# Altium schematic sheet battery_charger.SchDoc
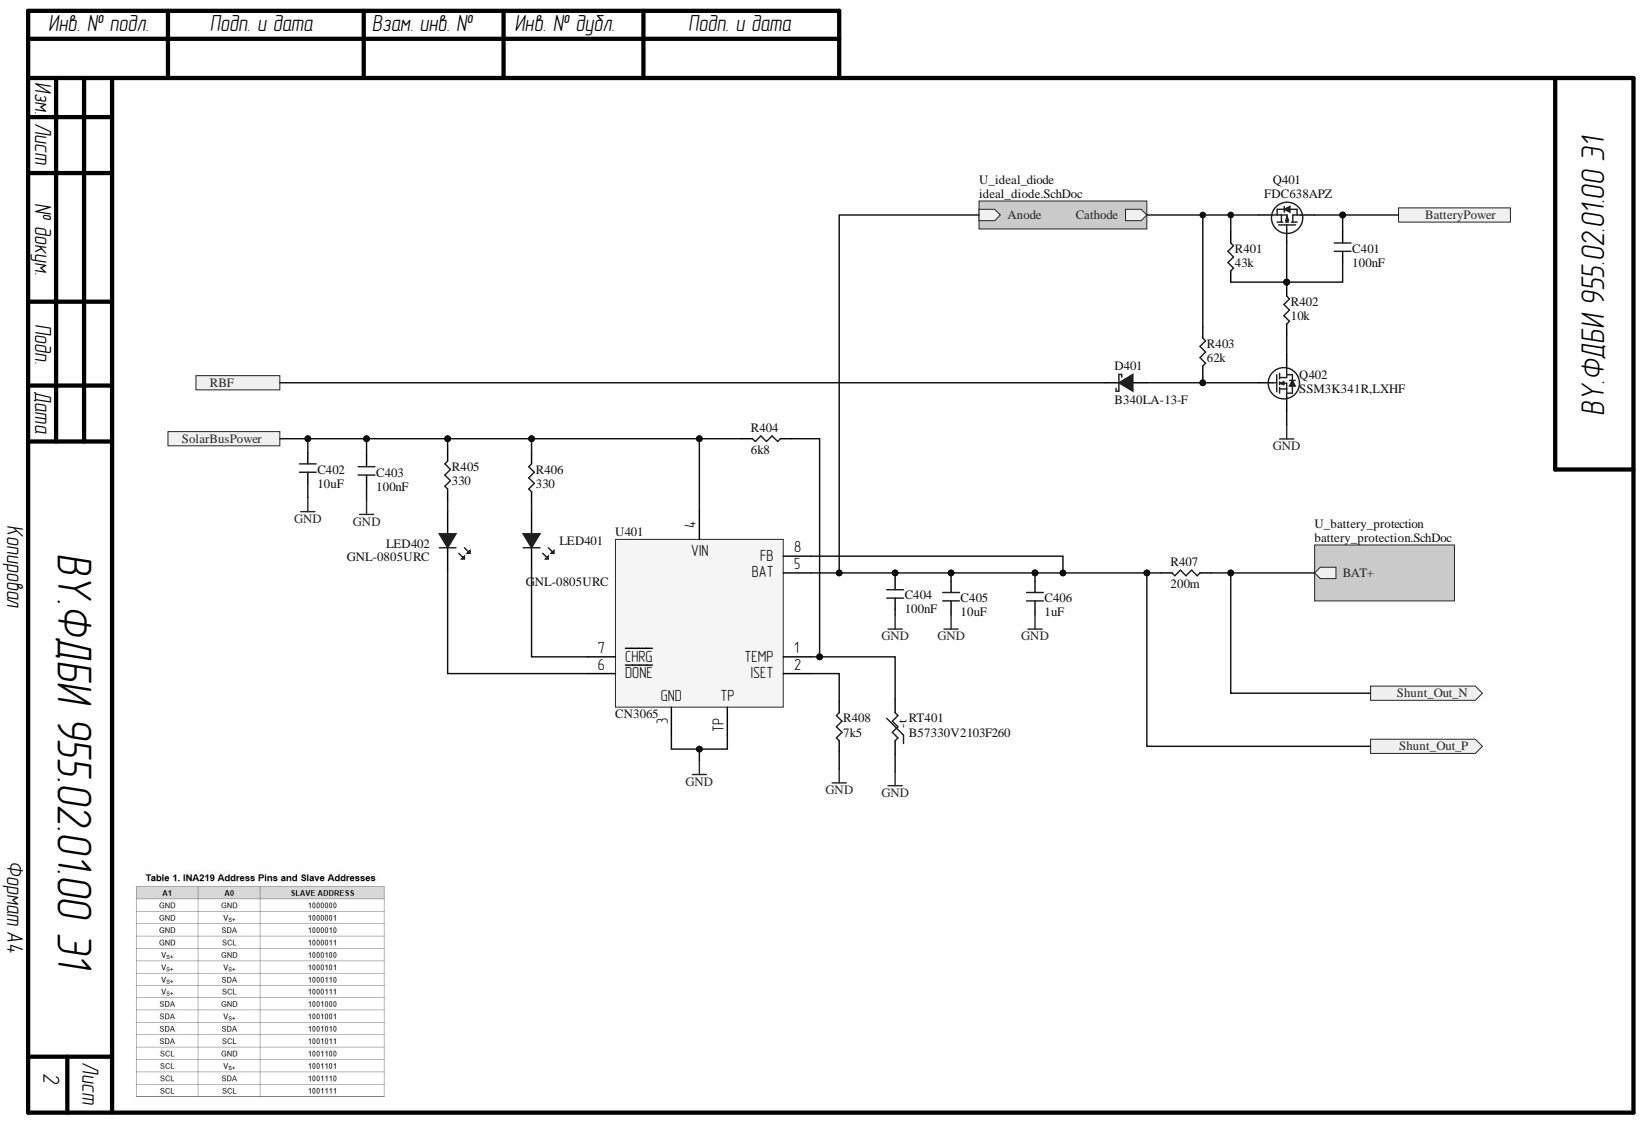
[diagram p0: battery_charger.SchDoc]

## Parts
C401  100nF  [CAP0603]
C402  10uF  [CAP0805]
C403  100nF  [CAP0603]
C404  100nF  [CAP0603]
C405  10uF  [CAP0805]
C406  1uF  [CAP0603]
D401  B340LA-13-F  [DO-214AC, SMA]
LED401  GNL-0805URC  [LED0805]
LED402  GNL-0805URC  [LED0805]
Q401  FDC638APZ  [SOT-23-6-MOSFET]
Q402  SSM3K341R,LXHF  [SOT-23-3-MOSFET]
R401  43k  [RES0603]
R402  10k  [RES0603]
R403  62k  [RES0603]
R404  6k8  [RES0603]
R405  330  [RES0603]
R406  330  [RES0603]
R407  200m  [RES1206]
R408  7k5  [RES0603]
RT401  B57330V2103F260  [RES0603]
U401  CN3065  [DFN-8_3x3_05]

## Ports
BatteryPower, RBF, Shunt_Out_N, Shunt_Out_P, SolarBusPower

## Nets
GND: C402.1 C403.1 C404.1 C405.1 C406.1 Q402.S R408.1 RT401.1 U401.3 U401.TP
BatteryPower: C401.2 Q401.D ; port BatteryPower
RBF: D401.1 ; port RBF
Shunt_Out_N: R407.2 ; port Shunt_Out_N
Shunt_Out_P: C404.2 C405.2 C406.2 R407.1 U401.5(BAT) U401.8(FB) ; port Shunt_Out_P
SolarBusPower: C402.2 C403.2 R404.1 R405.2 R406.2 U401.4 ; port SolarBusPower
NetC401_1: C401.1 Q401.G R401.1 R402.2
NetD401_2: D401.2 Q402.G R403.1
NetLED401_A: LED401.A R406.1
NetLED401_C: LED401.C U401.7(CHRG)
NetLED402_A: LED402.A R405.1
NetLED402_C: LED402.C U401.6(DONE)
NetQ401_S: Q401.S R401.2 R403.2
NetQ402_D: Q402.D R402.1
NetR404_2: R404.2 RT401.2 U401.1(TEMP)
NetR408_2: R408.2 U401.2(ISET)

## Library data of the parts
C401 C403 C404 = GCM188R71H104KA57J: Tolerance ±10% Rated Voltage 50Vdc Temperature 0±30ppm/℃; Manufacturer: Arrow Electronics; Database Table Name: Capacitors$; Item: 100nF 50V  ±10%; Vdc: 50V; Case: 0603; Category: Multilayer Ceramic Capacitors - SMD/SMT; Component Kind: Standard; HelpURL: http://www.farnell.com/datasheets/4360.pdf?src-supplier=Element14; Part Number: GCM188R71H104KA57J; Supplier 1: Mouser; Supplier Part Number 1: 81-GCM188R71H104KA7J; Tolerance: 10%; Value: 100nF; Library Name: Lib_Kam.DbLib
C402 C405 = GRJ21BR61E106KE01K: Tolerance ±10% Rated Voltage 25Vdc Dielectric:  X5R; Manufacturer: Murata; Database Table Name: Capacitors$; Item: 10uF 25V ±10%; Vdc: 25V; Case: 0805; Category: Multilayer Ceramic Capacitors - SMD/SMT; Component Kind: Standard; HelpURL: https://eu.mouser.com/datasheet/2/281/GRJ21BR61E106KE01_01-1977960.pdf; Part Number: GRJ21BR61E106KE01K; Supplier 1: Mouser; Supplier Part Number 1: 81-GRJ21BR61E106KE1K; Tolerance: 10%; Value: 10uF; Library Name: Lib_Kam.DbLib
C406 = CGA3E1X8L1C105K080AC: Tolerance ±10% Rated Voltage 16V Dielectric: X8L; Manufacturer: TDK; Database Table Name: Capacitors$; Item: 1uF 16V ±10%; Tolerance: 0.1; Case: 0603; Category: Multilayer Ceramic Capacitors - SMD/SMT; Component Kind: Standard; HelpURL: https://product.tdk.com/system/files/dam/doc/product/capacitor/ceramic/mlcc/catalog/mlcc_automotive_hightemp_en.pdf; Part Number: CGA3E1X8L1C105K080AC; Supplier 1: Mouser; Supplier Part Number 1: 810-CGA3E1X8L105K080; Value: 1uF; Vdc: 16V; Library Name: Lib_Kam.DbLib
D401 = B340LA-13-F: Diode Schottky 40 V 3A  (DC) Surface Mount DO-214AA-2; Manufacturer: Diodes Incorporated; Database Table Name: Diode$; Vdss: 40V; Case: SMA; Category: Schottky Diodes & Rectifiers; Component Kind: Standard; HelpURL: https://eu.mouser.com/datasheet/2/115/DIOD_S_A0003383600_1-2542483.pdf; Id: 3A; Item: B340LA-13-F; Part Number: B340LA-13-F; Supplier 1: Mouser; Supplier Part Number 1: 621-B340LA-F; Type: Diode Schottky; Library Name: Lib_Kam.DbLib
LED401 LED402 = GNL-0805URC: Standard LEDs - SMD Red; Manufacturer: G-NOR; Database Table Name: LED$; Imax: 30mA; Value: 1.9V; Case: 0805; Category: Standard LEDs - SMD; Color: Red; Component Kind: Standard; HelpURL: https://belchip.by/sitedocs/gnl0805.pdf; Item: GNL-0805URC; Part Number: GNL-0805URC; Supplier 1: Belchip; Supplier Part Number 1: 00948; Library Name: Lib_Kam.DbLib
Q401 = FDC638APZ: P-Channel 20 V 4.5A Surface Mount SOT-23-6; Manufacturer: NXP; Database Table Name: Transistors$; Vds: 20V; Case: SOT-23-6; Category: MOSFET; Channel: P-Channel; Component Kind: Standard; HelpURL: https://belchip.by/sitedocs/45764.pdf; Id: 4.5A; Item: FDC638APZ; Part Number: FDC638APZ; Supplier 1: BelChip; Supplier Part Number 1: 45764; Library Name: Lib_Kam.DbLib
Q402 = SSM3K341R,LXHF: MOSFET N Channel 60V 6A AECQ MOSFET; Manufacturer: Toshiba; Channel: N-Channel; Database Table Name: Transistors$; Case: SOT-23F-3; Category: MOSFET; Component Kind: Standard; HelpURL: https://eu.mouser.com/datasheet/2/408/SSM3K341R_datasheet_en_20210203-958611.pdf; Id: 6A; Item: SSM3K341R,LXHF; Part Number: SSM3K341R,LXHF; Supplier 1: Mouser; Supplier Part Number 1: 757-SSM3K341RLXHF; Vds: 60V; Library Name: Lib_Kam.DbLib
R401 = CR0603-FX-4302ELF: Tolerance ±1% Power (Watts) 0.1W, 1/10W Temperature Coefficient ±50ppm/°C; Manufacturer: Bourns; Database Table Name: Resistors$; Item: 43k ±1% 0.1W; Case: 0603; Category: Thin Film Resistors - SMD; Component Kind: Standard; HelpURL: https://eu.mouser.com/datasheet/2/54/CRT-1649066.pdf; Part Number: CR0603-FX-4302ELF; Supplier 1: Mouser; Supplier Part Number 1: 652-CR0603FX-4302ELF; Tolerance: 1%; Value: 43k; Library Name: Lib_Kam.DbLib
R402 = CR0603-FX-1002ELF: Tolerance ±1% Power (Watts) 0.1W, 1/10W Temperature Coefficient ±100ppm/°C; Manufacturer: Bourns; Database Table Name: Resistors$; Item: 10k  ±1% 0.1W; Case: 0603; Category: Thin Film Resistors - SMD; Component Kind: Standard; HelpURL: https://eu.mouser.com/datasheet/2/54/cr-1858361.pdf; Part Number: CR0603-FX-1002ELF; Supplier 1: Mouser; Supplier Part Number 1: 652-CR0603FX-1002ELF; Tolerance: 1%; Value: 10k; Library Name: Lib_Kam.DbLib
R403 = ERA-3AEB623V: Tolerance ±0.1% Power (Watts) 0.1W, 1/10W Temperature Coefficient ±100ppm/°C; Manufacturer: Panasonic; Database Table Name: Resistors$; Item: 62k  ±0.1% 0.1W; Case: 0603; Category: Thin Film Resistors - SMD; Component Kind: Standard; HelpURL: https://www.distrelec.biz/Web/Downloads/_t/ds/ERA_eng_tds.pdf?mime=application%2Fpdf&src-supplier=Distrelec; Part Number: ERA-3AEB623V; Supplier 1: Mouser; Supplier Part Number 1: 667-ERA-3AEB623V; Tolerance: 0.1%; Value: 62k; Library Name: Lib_Kam.DbLib
R404 = RC0603FR-076K8L: Tolerance ±1% Power (Watts) 0.1W, 1/10W Temperature Coefficient ±100ppm/°C; Manufacturer: YAGEO; Database Table Name: Resistors$; Item: 6k8 ±1% 0.1W; Case: 0603; Category: Thin Film Resistors - SMD; Component Kind: Standard; HelpURL: https://eu.mouser.com/datasheet/2/54/cr-1858361.pdf; Part Number: RC0603FR-076K8L; Supplier 1: Mouser; Supplier Part Number 1: 603-RC0603FR-076K8L; Tolerance: 1%; Value: 6k8; Library Name: Lib_Kam.DbLib
R405 R406 = CMP0603-FX-3300ELF: Tolerance ±1% Power (Watts) 0.25W, 1/4W Temperature Coefficient ±100ppm/°C; Manufacturer: Bourns; Database Table Name: Resistors$; Item: 330  ±1% 0.25W; Case: 0603; Category: Thin Film Resistors - SMD; Component Kind: Standard; HelpURL: https://eu.mouser.com/datasheet/2/54/Bourns_CMP_Datasheet_05.28.20-1854233.pdf; Part Number: CMP0603-FX-3300ELF; Supplier 1: Mouser; Supplier Part Number 1: 652-CMP0603-FX-3300L; Tolerance: 1%; Value: 330; Library Name: Lib_Kam.DbLib
R407 = WSL1206R2000FTA: Tolerance ±1% Power (Watts) 0.25W, 1/4W Temperature Coefficient ±75ppm/°C; Manufacturer: Vishay; Database Table Name: Resistors$; Item: 200m  ±1% 0.25W; Tolerance: 0.01; Case: 1206; Category: Thin Film Resistors - SMD; Component Kind: Standard; HelpURL: https://eu.mouser.com/datasheet/2/427/VISH_S_A0011931981_1-2572395.pdf; Part Number: WSL1206R2000FTA; Supplier 1: Mouser; Value: 200m; Library Name: Lib_Kam.DbLib
R408 = CR0603-FX-7501ELF: Tolerance ±1% Power (Watts) 0.1W, 1/10W Temperature Coefficient ±100ppm/°C; Manufacturer: Bourns; Database Table Name: Resistors$; Item: 7.5k  ±1% 0.1W; Case: 0603; Category: Thin Film Resistors - SMD; Component Kind: Standard; HelpURL: https://www.bourns.com/docs/product-datasheets/cr.pdf?sfvrsn=574d41f6_14; Part Number: CR0603-FX-7501ELF; Supplier 1: Mouser; Supplier Part Number 1: 652-CR0603FX-7501ELF; Tolerance: 1%; Value: 7k5; Library Name: Lib_Kam.DbLib
RT401 = B57330V2103F260: NTC (Negative Temperature Coefficient) Thermistors 0603 10K 1% B-3455 NTC; Manufacturer: EPCOS / TDK; B Parametr: 3455K; Database Table Name: NTC_PTC$; Power Rating: 180mW; Case: 0603; Category: NTC Thermistors; Component Kind: Standard; HelpURL: https://product.tdk.com/system/files/dam/doc/product/sensor/ntc/chip-ntc-thermistor/data_sheet/50/db/ntc/ntc_smd_standard_series_0603.pdf?ref_disty=mouser; Item: B57330V2103F260; Part Number: B57330V2103F260; Supplier 1: Mouser; Supplier Part Number 1: 871-B57330V2103F260; Tolerance: 1%; Value: 10k; Library Name: Lib_Kam.DbLib
U401 = CN3065: Lithium Ion Battery Charger for Solar-Powered Systems; Manufacturer: CONSONANCE; Database Table Name: Battery_Management$; Case: DFN-8; Category: Battery Management; Component Kind: Standard; HelpURL: https://raw.githubusercontent.com/SeeedDocument/Lipo_Rider_Pro/master/res/DSE-CN3065.pdf; Item: CN3065; Part Number: CN3065; Supplier 1: Chip-Dip; Supplier Part Number 1: CN3065; Library Name: Lib_Kam.DbLib
